# Altium schematic sheet Weekday.SchDoc
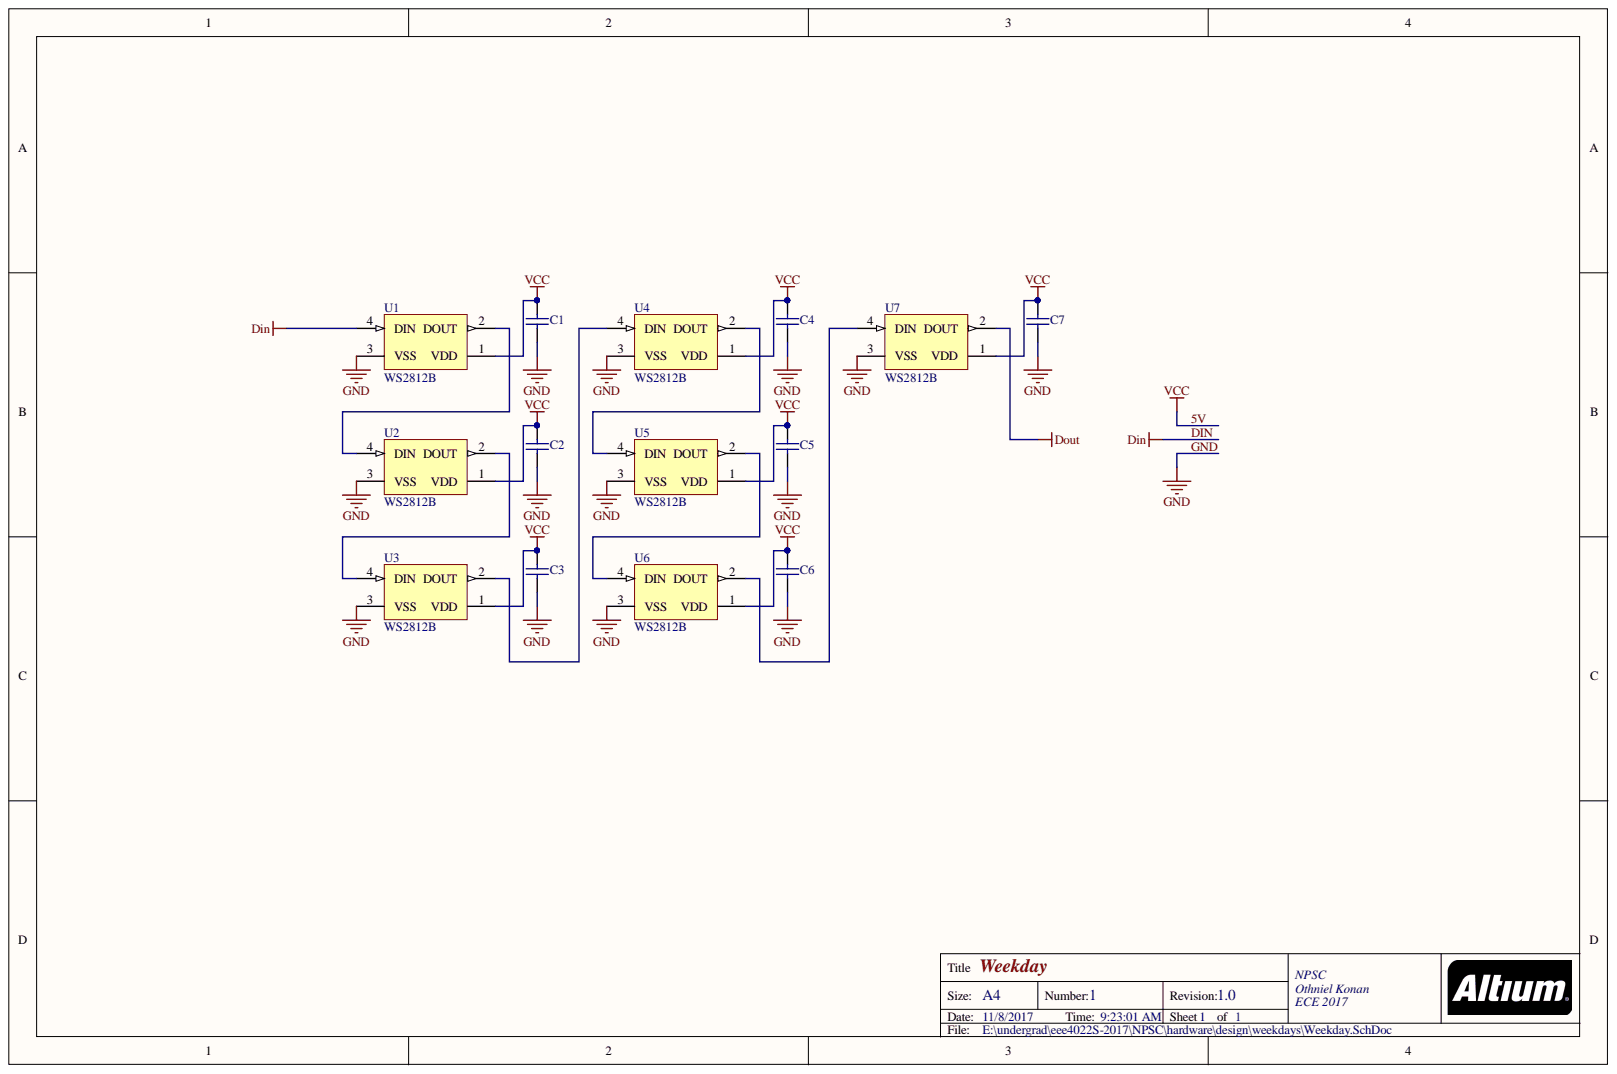
[diagram p0: Weekday.SchDoc]

## Parts
C1  CAP 0.5pF 10V 0805(2012)  [CAPC0805(2012)100_L]  (value not drawn)
C2  CAP 0.5pF 10V 0805(2012)  [CAPC0805(2012)100_L]  (value not drawn)
C3  CAP 0.5pF 10V 0805(2012)  [CAPC0805(2012)100_L]  (value not drawn)
C4  CAP 0.5pF 10V 0805(2012)  [CAPC0805(2012)100_L]  (value not drawn)
C5  CAP 0.5pF 10V 0805(2012)  [CAPC0805(2012)100_L]  (value not drawn)
C6  CAP 0.5pF 10V 0805(2012)  [CAPC0805(2012)100_L]  (value not drawn)
C7  CAP 0.5pF 10V 0805(2012)  [CAPC0805(2012)100_L]  (value not drawn)
U1  WS2812B  [ADAI-WS2812B_V]
U2  WS2812B  [ADAI-WS2812B_V]
U3  WS2812B  [ADAI-WS2812B_V]
U4  WS2812B  [ADAI-WS2812B_V]
U5  WS2812B  [ADAI-WS2812B_V]
U6  WS2812B  [ADAI-WS2812B_V]
U7  WS2812B  [ADAI-WS2812B_V]

## Nets
Dout: U7.2(DOUT)
5V: C1.2 C2.2 C3.2 C4.2 C5.2 C6.2 C7.2 U1.1(VDD) U2.1(VDD) U3.1(VDD) U4.1(VDD) U5.1(VDD) U6.1(VDD) U7.1(VDD)
DIN: U1.4(DIN)
GND: C1.1 C2.1 C3.1 C4.1 C5.1 C6.1 C7.1 U1.3(VSS) U2.3(VSS) U3.3(VSS) U4.3(VSS) U5.3(VSS) U6.3(VSS) U7.3(VSS)
NetU1_2: U1.2(DOUT) U2.4(DIN)
NetU2_2: U2.2(DOUT) U3.4(DIN)
NetU3_2: U3.2(DOUT) U4.4(DIN)
NetU4_2: U4.2(DOUT) U5.4(DIN)
NetU5_2: U5.2(DOUT) U6.4(DIN)
NetU6_2: U6.2(DOUT) U7.4(DIN)

## Library data of the parts
C1-C7 = CMP-1036-00005-1: CAP 0.5pF 10V ±0.5pF 0805 (2012 Metric) Thickness 1mm SMD; Case-EIA: 0805; Case-Metric: 2012; Tolerance: Â±0.5pF; Component Kind: Standard; Library Name: Altium Content Vault; Max Thickness: 1 mm; Rated Voltage: 10 V; Technology: SMT; Value: 0.5pF
U1-U7 = CMP-1740-00005-1: Intelligent Control LED Integrated Light Source, 3.5 to 5.3 V, -25 to 80 degC, 4-Pin SMD, RoHS, Bulk; Case\Package: Radial; Current Rating: 240 mA; Shielding: Unshielded; Tolerance: 10%; Component Kind: Standard; ComponentLink1Description: Manufacturer URL; ComponentLink1URL: https://www.adafruit.com/; ComponentLink2Description: Datasheet; ComponentLink2URL: https://cdn-shop.adafruit.com/datasheets/WS2812B.pdf; DatasheetVersion: May-13; Height: 12.2 mm; Lead Pitch: 5 mm; Length: 12.2 mm; Library Name: Altium Content Vault; Max Operating Temperature: 125 degC; Min Operating Temperature: -55 degC; Mount: Through Hole; PackageDescription: 4-Pin Surface Mount Device, Body 5 x 5 mm, Pitch 3.3 mm; Package Quantity: 200; PackageReference: WS2812B; Packaging: Bulk; Pins: 2; Radiation Hardening: No; REACH SVHC: No SVHC; RoHSCompliant: true
